# Altium schematic sheet TI2025_DiffDrv.SchDoc(TI2025_DiffDrv)
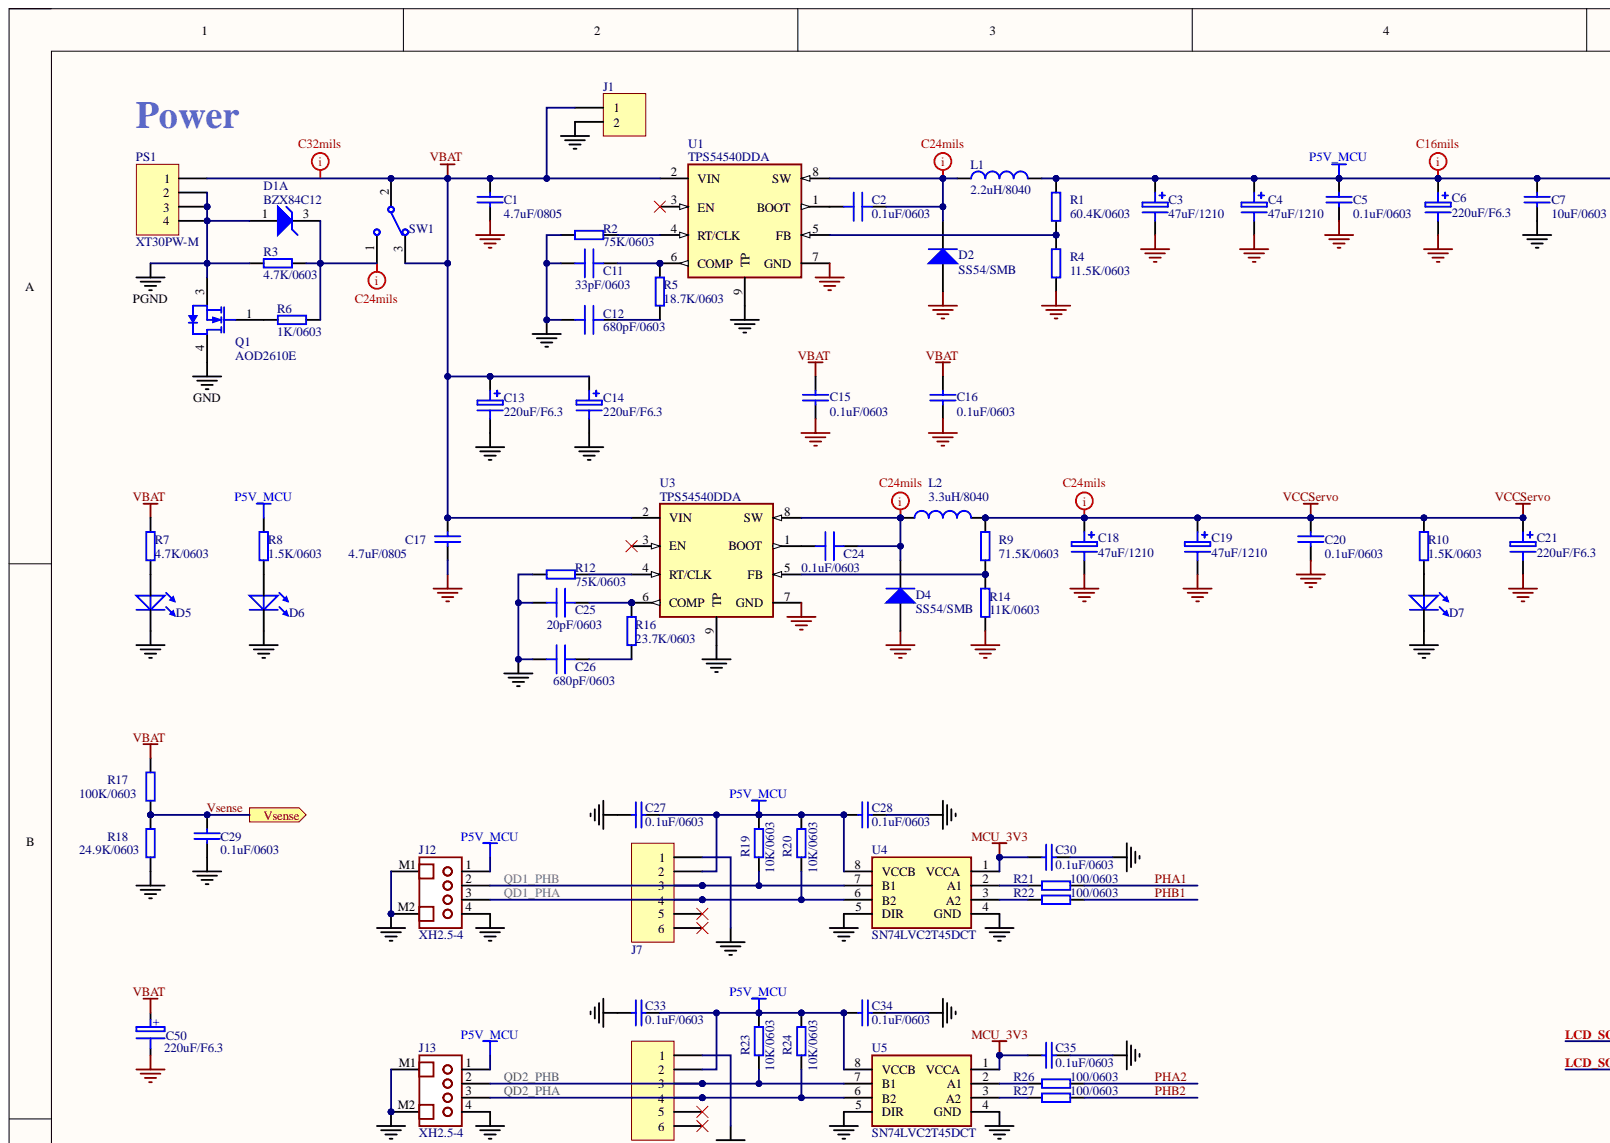
[diagram p0: TI2025_DiffDrv.SchDoc, top left]
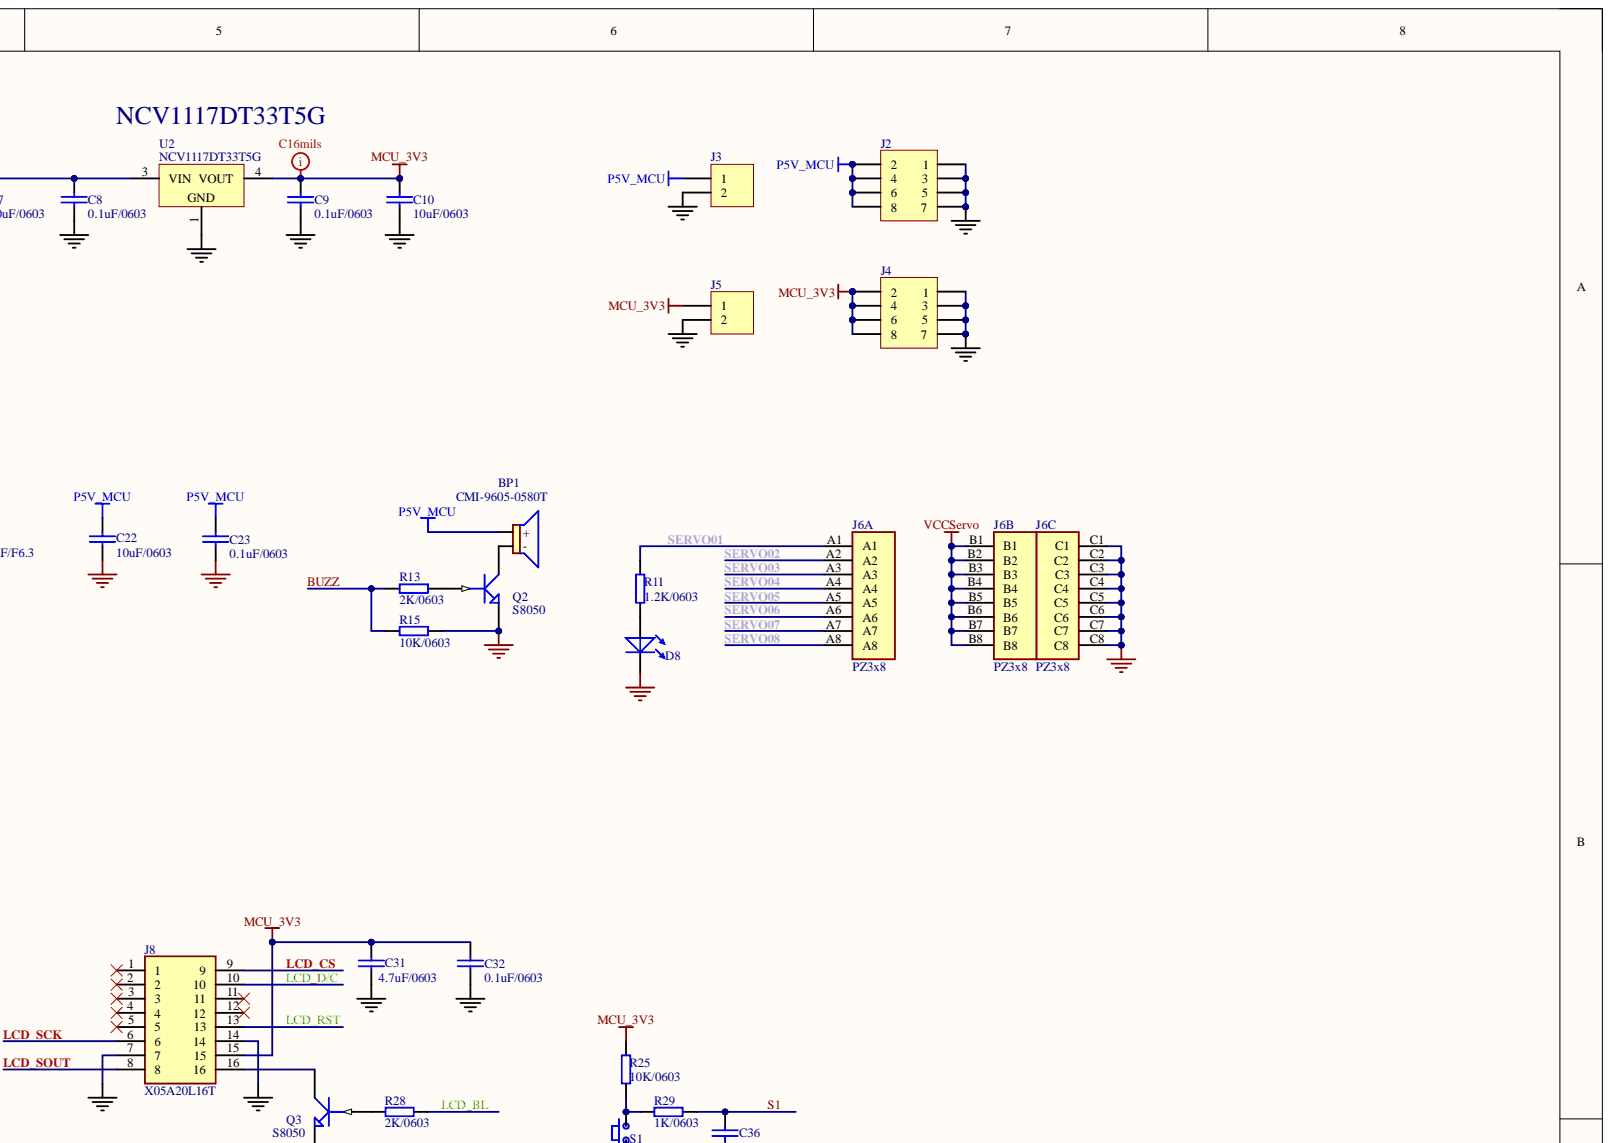
[diagram p1: TI2025_DiffDrv.SchDoc, top right]
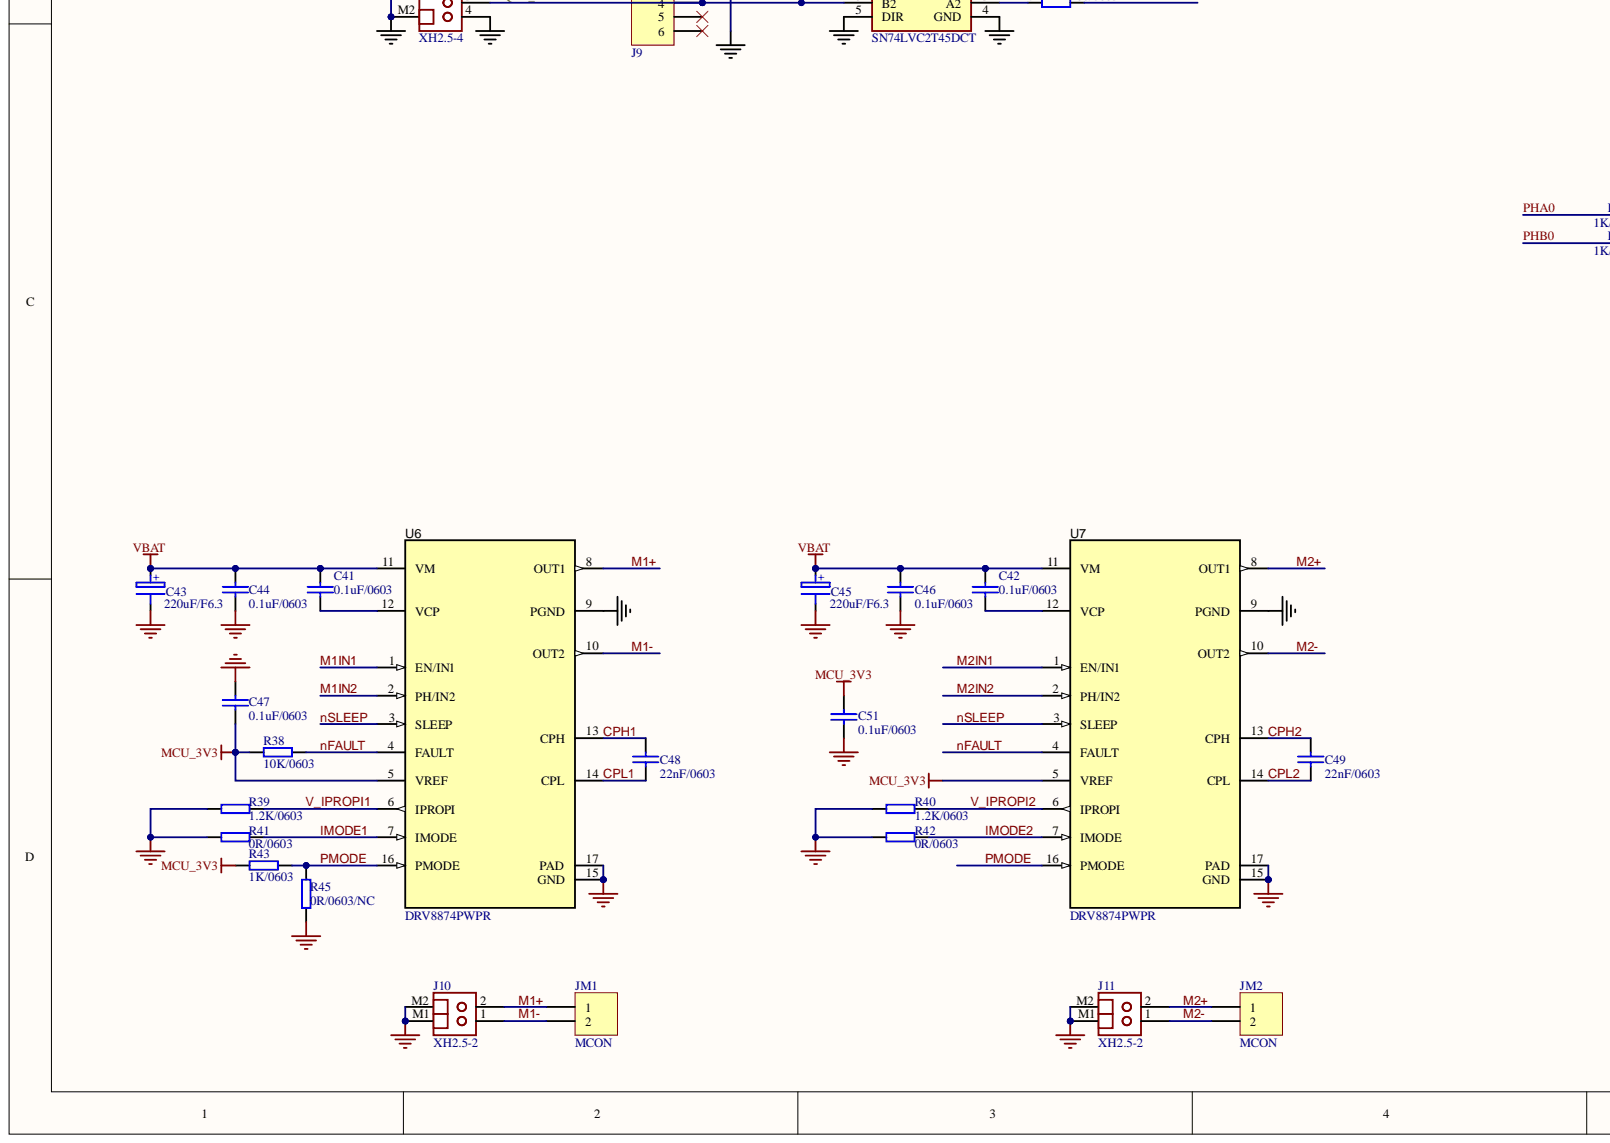
[diagram p2: TI2025_DiffDrv.SchDoc, bottom left]
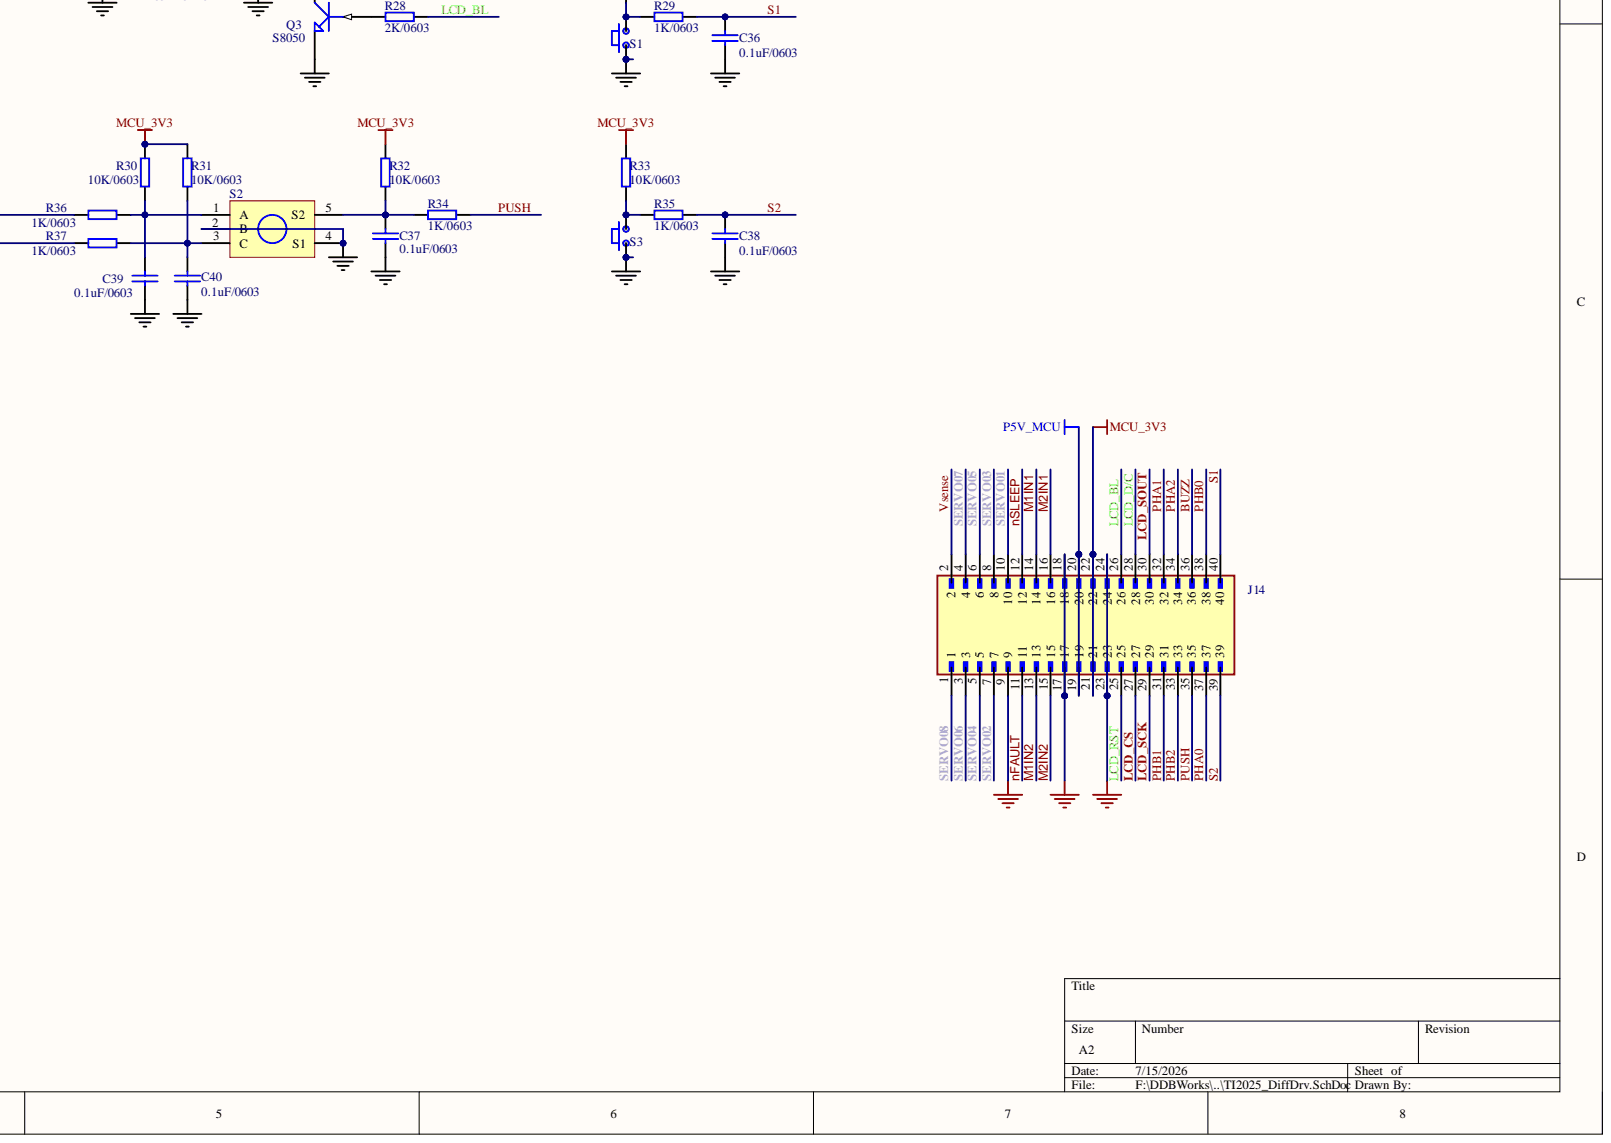
[diagram p3: TI2025_DiffDrv.SchDoc, bottom right]

## Parts
BP1  CMI-9605-0580T  [BUZZER_D9.6_P5.0]
C1  4.7uF/0805  [C0805J]
C2  0.1uF/0603  [C0603J]
C3  47uF/1210  [C1210J]
C4  47uF/1210  [C1210J]
C5  0.1uF/0603  [C0603J]
C6  220uF/F6.3  [SMD-EC-F6.3]
C7  10uF/0603  [C0603J]
C8  0.1uF/0603  [C0603J]
C9  0.1uF/0603  [C0603J]
C10  10uF/0603  [C0603J]
C11  33pF/0603  [C0603J]
C12  680pF/0603  [C0603J]
C13  220uF/F6.3  [SMD-EC-F6.3]
C14  220uF/F6.3  [SMD-EC-F6.3]
C15  0.1uF/0603  [C0603J]
C16  0.1uF/0603  [C0603J]
C17  4.7uF/0805  [C0805J]
C18  47uF/1210  [C1210J]
C19  47uF/1210  [C1210J]
C20  0.1uF/0603  [C0603J]
C21  220uF/F6.3  [SMD-EC-F6.3]
C22  10uF/0603  [C0603J]
C23  0.1uF/0603  [C0603J]
C24  0.1uF/0603  [C0603J]
C25  20pF/0603  [C0603J]
C26  680pF/0603  [C0603J]
C27  0.1uF/0603  [C0603J]
C28  0.1uF/0603  [C0603J]
C29  0.1uF/0603  [C0603J]
C30  0.1uF/0603  [C0603J]
C31  4.7uF/0603  [C0603J]
C32  0.1uF/0603  [C0603J]
C33  0.1uF/0603  [C0603J]
C34  0.1uF/0603  [C0603J]
C35  0.1uF/0603  [C0603J]
C36  0.1uF/0603  [C0603J]
C37  0.1uF/0603  [C0603J]
C38  0.1uF/0603  [C0603J]
C39  0.1uF/0603  [C0603J]
C40  0.1uF/0603  [C0603J]
C41  0.1uF/0603  [C0603J]
C42  0.1uF/0603  [C0603J]
C43  220uF/F6.3  [SMD-EC-F6.3]
C44  0.1uF/0603  [C0603J]
C45  220uF/F6.3  [SMD-EC-F6.3]
C46  0.1uF/0603  [C0603J]
C47  0.1uF/0603  [C0603J]
C48  22nF/0603  [C0603J]
C49  22nF/0603  [C0603J]
C50  220uF/F6.3  [SMD-EC-F6.3]
C51  0.1uF/0603  [C0603J]
D1  BZX84C12  [SOT23J]  (part A)
D2  SS54/SMB  [SMB_DTE]
D4  SS54/SMB  [SMB_DTE]
D5  LED/0603/R  [D0603J]  (value not drawn)
D6  LED/0603/R  [D0603J]  (value not drawn)
D7  LED/0603/R  [D0603J]  (value not drawn)
D8  LED/0603/R  [D0603J]  (value not drawn)
J1  (no value)  [CONN-2P-V3.5]
J2  2.54-2*4P  [IDC8DTE]  (value not drawn)
J3  (no value)  [CONN-2P-V3.5]
J4  2.54-2*4P  [IDC8DTE]  (value not drawn)
J5  (no value)  [CONN-2P-V3.5]
J6  PZ3x8  [PZ3x8-2.54]  (parts A,B,C)
J7  IDC2.54-6P  [IDC6Socket]  (value not drawn)
J8  X05A20L16T  [X05A20L16T]
J9  IDC2.54-6P  [IDC6Socket]  (value not drawn)
J10  XH2.5-2  [CONN-2P-XH2.5]
J11  XH2.5-2  [CONN-2P-XH2.5]
J12  XH2.5-4  [CONN-4P-XH2.5]
J13  XH2.5-4  [CONN-4P-XH2.5]
J14  IDC40P  [IDC40J]  (value not drawn)
JM1  MCON  [EDGRC-3.5-2P]
JM2  MCON  [EDGRC-3.5-2P]
L1  2.2uH/8040  [SWPA8040S]
L2  3.3uH/8040  [SWPA8040S]
PS1  XT30PW-M  [XT30PW-M]
Q1  AOD2610E  [TO-252A]
Q2  S8050  [S8050LT]
Q3  S8050  [S8050LT]
R1  60.4K/0603  [R0603J]
R2  75K/0603  [R0603J]
R3  4.7K/0603  [R0603J]
R4  11.5K/0603  [R0603J]
R5  18.7K/0603  [R0603J]
R6  1K/0603  [R0603J]
R7  4.7K/0603  [R0603J]
R8  1.5K/0603  [R0603J]
R9  71.5K/0603  [R0603J]
R10  1.5K/0603  [R0603J]
R11  1.2K/0603  [R0603J]
R12  75K/0603  [R0603J]
R13  2K/0603  [R0603J]
R14  11K/0603  [R0603J]
R15  10K/0603  [R0603J]
R16  23.7K/0603  [R0603J]
R17  100K/0603  [R0603J]
R18  24.9K/0603  [R0603J]
R19  10K/0603  [R0603J]
R20  10K/0603  [R0603J]
R21  100/0603  [R0603J]
R22  100/0603  [R0603J]
R23  10K/0603  [R0603J]
R24  10K/0603  [R0603J]
R25  10K/0603  [R0603J]
R26  100/0603  [R0603J]
R27  100/0603  [R0603J]
R28  2K/0603  [R0603J]
R29  1K/0603  [R0603J]
R30  10K/0603  [R0603J]
R31  10K/0603  [R0603J]
R32  10K/0603  [R0603J]
R33  10K/0603  [R0603J]
R34  1K/0603  [R0603J]
R35  1K/0603  [R0603J]
R36  1K/0603  [R0603J]
R37  1K/0603  [R0603J]
R38  10K/0603  [R0603J]
R39  1.2K/0603  [R0603J]
R40  1.2K/0603  [R0603J]
R41  0R/0603  [R0603J]
R42  0R/0603  [R0603J]
R43  1K/0603  [R0603J]
R45  0R/0603/NC  [R0603J]
S1  PB2  [PB2P_THRU]  (value not drawn)
S2  QES  [QES_THRU]  (value not drawn)
S3  PB2  [PB2P_THRU]  (value not drawn)
SW1  SS12D10G4  [SS12D10]  (value not drawn)
U1  TPS54540DDA  [SOIC8DTE_EP]
U2  NCV1117DT33T5G  [TO-252A]
U3  TPS54540DDA  [SOIC8DTE_EP]
U4  SN74LVC2T45DCT  [DCT_SSOP_SM8]
U5  SN74LVC2T45DCT  [DCT_SSOP_SM8]
U6  DRV8874PWPR  [PWP0016J_N]
U7  DRV8874PWPR  [PWP0016J_N]

## Ports
Vsense

## Nets
GND: C1.1 C3.2 C4.2 C5.1 C6.2 C7.2 C8.1 C9.1 C10.2 C11.2 C12.2 C13.2 C14.2 C15.2 C16.2 C17.1 C18.2 C19.2 C20.1 C21.2 C22.1 C23.1 C25.2 C26.2 C27.2 C28.1 C29.1 C30.1 C31.2 C32.1 C33.2 C34.1 C35.1 C36.1 C37.2 C38.1 C39.2 C40.2 C43.2 C44.1 C45.2 C46.1 C47.1 C50.2 C51.2 D2.1 D4.1 D5.K D6.K D7.K D8.K J1.2 J2.1 J2.3 J2.5 J2.7 J3.2 J4.1 J4.3 J4.5 J4.7 J5.2 J6.C1 J6.C2 J6.C3 J6.C4 J6.C5 J6.C6 J6.C7 J6.C8 J7.1 J8.7 J8.14 J9.1 J10.M1 J10.M2 J11.M1 J11.M2 J12.4 J12.M1 J12.M2 J13.4 J13.M1 J13.M2 J14.9 J14.17 J14.18 J14.23 J14.24 Q1.4 Q2.3 Q3.3 R2.1 R4.1 R12.1 R14.1 R15.1 R18.1 R39.1 R40.1 R41.1 R42.1 R45.1 S1.1 S2.2(B) S2.4(S1) S3.1 U1.7(GND) U1.9(TP) U2.1 U3.7(GND) U3.9(TP) U4.4(GND) U4.5(DIR) U5.4(GND) U5.5(DIR) U6.9(PGND) U6.15(GND) U6.17(PAD) U7.9(PGND) U7.15(GND) U7.17(PAD)
MCU_3V3: C9.2 C10.1 C30.2 C31.1 C32.2 C35.2 C47.2 C51.1 J4.2 J4.4 J4.6 J4.8 J5.1 J8.15 J14.21 J14.22 R25.1 R30.1 R31.1 R32.1 R33.1 R38.1 R43.1 U2.4 U4.1(VCCA) U5.1(VCCA) U6.5(VREF) U7.5(VREF)
P5V_MCU: BP1.1 C3.1 C4.1 C5.2 C6.1 C7.1 C8.2 C22.2 C23.2 C27.1 C28.2 C33.1 C34.2 J2.2 J2.4 J2.6 J2.8 J3.1 J7.2 J9.2 J12.1 J13.1 J14.19 J14.20 L1.2 R1.1 R8.2 R19.1 R20.1 R23.1 R24.1 U2.3 U4.8(VCCB) U5.8(VCCB)
PGND: D1.1 PS1.2 PS1.3 PS1.4 Q1.3 R3.2
VBAT: C1.2 C13.1 C14.1 C15.1 C16.1 C17.2 C41.2 C42.2 C43.1 C44.2 C45.1 C46.2 C50.1 J1.1 PS1.1 R7.2 R17.2 SW1.2 SW1.3 U1.2(VIN) U3.2(VIN) U6.11(VM) U7.11(VM)
VCCServo: C18.1 C19.1 C20.2 C21.1 J6.B1 J6.B2 J6.B3 J6.B4 J6.B5 J6.B6 J6.B7 J6.B8 L2.2 R9.1 R10.1
BUZZ: J14.36 R13.1 R15.2
CPH1: C48.2 U6.13(CPH)
CPH2: C49.2 U7.13(CPH)
CPL1: C48.1 U6.14(CPL)
CPL2: C49.1 U7.14(CPL)
IMODE1: R41.2 U6.7(IMODE)
IMODE2: R42.2 U7.7(IMODE)
LCD_BL: J14.26 R28.2
LCD_CS: J8.9 J14.27
LCD_D/C: J8.10 J14.28
LCD_RST: J8.13 J14.25
LCD_SCK: J8.6 J14.29
LCD_SOUT: J8.8 J14.30
M1+: J10.2 JM1.1 U6.8(OUT1)
M1-: J10.1 JM1.2 U6.10(OUT2)
M1IN1: J14.14 U6.1(EN/IN1)
M1IN2: J14.13 U6.2(PH/IN2)
M2+: J11.2 JM2.1 U7.8(OUT1)
M2-: J11.1 JM2.2 U7.10(OUT2)
M2IN1: J14.16 U7.1(EN/IN1)
M2IN2: J14.15 U7.2(PH/IN2)
nFAULT: J14.11 R38.2 U6.4(FAULT) U7.4(FAULT)
nSLEEP: J14.12 U6.3(SLEEP) U7.3(SLEEP)
PHA0: J14.37 R36.1
PHA1: J14.32 R21.2
PHA2: J14.34 R26.2
PHB0: J14.38 R37.1
PHB1: J14.31 R22.2
PHB2: J14.33 R27.2
PMODE: R43.2 R45.2 U6.16(PMODE) U7.16(PMODE)
PUSH: J14.35 R34.2
QD1_PHA: J7.4 J12.3 R20.2 U4.6(B2)
QD1_PHB: J7.3 J12.2 R19.2 U4.7(B1)
QD2_PHA: J9.4 J13.3 R24.2 U5.6(B2)
QD2_PHB: J9.3 J13.2 R23.2 U5.7(B1)
S1: C36.2 J14.40 R29.2
S2: C38.2 J14.39 R35.2
SERVO01: J6.A1 J14.10 R11.2
SERVO02: J6.A2 J14.7
SERVO03: J6.A3 J14.8
SERVO04: J6.A4 J14.5
SERVO05: J6.A5 J14.6
SERVO06: J6.A6 J14.3
SERVO07: J6.A7 J14.4
SERVO08: J6.A8 J14.1
V_IPROPI1: R39.2 U6.6(IPROPI)
V_IPROPI2: R40.2 U7.6(IPROPI)
Vsense: C29.2 J14.2 R17.1 R18.2 ; port Vsense
NetBP1_2: BP1.2 Q2.2
NetC2_1: C2.1 D2.2 L1.1 U1.8(SW)
NetC2_2: C2.2 U1.1(BOOT)
NetC11_1: C11.1 R5.2 U1.6(COMP)
NetC12_1: C12.1 R5.1
NetC24_1: C24.1 D4.2 L2.1 U3.8(SW)
NetC24_2: C24.2 U3.1(BOOT)
NetC25_1: C25.1 R16.2 U3.6(COMP)
NetC26_1: C26.1 R16.1
NetC37_1: C37.1 R32.2 R34.1 S2.5
NetC39_1: C39.1 R30.2 R36.2 S2.1(A)
NetC40_1: C40.1 R31.2 R37.2 S2.3(C)
NetC41_1: C41.1 U6.12(VCP)
NetC42_1: C42.1 U7.12(VCP)
NetD1_3: D1.3 R3.1 R6.1 SW1.1
NetD5_A: D5.A R7.1
NetD6_A: D6.A R8.1
NetD7_A: D7.A R10.2
NetD8_A: D8.A R11.1
NetJ8_16: J8.16 Q3.2
NetQ1_1: Q1.1 R6.2
NetQ2_1: Q2.1 R13.2
NetQ3_1: Q3.1 R28.1
NetR1_2: R1.2 R4.2 U1.5(FB)
NetR2_2: R2.2 U1.4(RT/CLK)
NetR9_2: R9.2 R14.2 U3.5(FB)
NetR12_2: R12.2 U3.4(RT/CLK)
NetR21_1: R21.1 U4.2(A1)
NetR22_1: R22.1 U4.3(A2)
NetR25_2: R25.2 R29.1 S1.2
NetR26_1: R26.1 U5.2(A1)
NetR27_1: R27.1 U5.3(A2)
NetR33_2: R33.2 R35.1 S3.2

Not connected: J7 5 6; J8 1 2 3 4 5 11 12; J9 5 6; U1 3(EN); U3 3(EN)

## Library data of the parts
BP1 = BUZZER: C200213; Component Kind: Standard; Library Name: ELVIS4.SchLib
C1 C17 = CAP: C1779; Component Kind: Standard; Part Field 1: 8-Jun-2000; Part Field 2: July-2002: Re-released for DXP Platform.; Part Field 3: Altium Limited; Part Field 4: 30pF; PCB3D: 0805_0_0000_C
C2 C24 = Ca_DTE: C14663; Component Kind: Standard; Library Name: DTE1.SchLib
C3 C4 C18 C19 = CAPPOLSMD: C77101; Component Kind: Standard
C5 C8 C9 C15 C16 C20 C23 C27-C30 C32-C36 C38 C41 C42 C44 C46 C47 C51 = CAP: C14663; Component Kind: Standard; Part Field 1: 8-Jun-2000; Part Field 2: July-2002: Re-released for DXP Platform.; Part Field 3: Altium Limited; Part Field 4: 30pF; PCB3D: 0805_0_0000_C
C6 C13 C14 C21 = CAPPOLSMD: C4747975; Component Kind: Standard
C7 C10 C22 = CAP: C96446; Component Kind: Standard; Part Field 1: 8-Jun-2000; Part Field 2: July-2002: Re-released for DXP Platform.; Part Field 3: Altium Limited; Part Field 4: 30pF; PCB3D: 0805_0_0000_C
C11 = Ca_DTE: C1658; Component Kind: Standard; Library Name: DTE1.SchLib
C12 C26 = Ca_DTE: C1630; Component Kind: Standard; Library Name: DTE1.SchLib
C25 = Ca_DTE: C1648; Component Kind: Standard; Library Name: DTE1.SchLib
C31 = CAP: C19666; Component Kind: Standard; Part Field 1: 8-Jun-2000; Part Field 2: July-2002: Re-released for DXP Platform.; Part Field 3: Altium Limited; Part Field 4: 30pF; PCB3D: 0805_0_0000_C
C37 C39 C40 = CAP: C14663; Part Field 1: C1525; Component Kind: Standard; Part Field 2: CL05B104KO5NNNC; Part Field 3: Altium Limited; Part Field 4: 0.1uF 16V
C43 C45 C50 = Cb_DTE: C4747975; Component Kind: Standard; Library Name: DTE1.SchLib; PCB3D: C3216-1206_0_0000_C
C48 C49 = CAP: C21122; Component Kind: Standard; Part Field 1: 8-Jun-2000; Part Field 2: July-2002: Re-released for DXP Platform.; Part Field 3: Altium Limited; Part Field 4: 30pF; PCB3D: 0805_0_0000_C
D1 = BZX84C12LT1G: C82475; Manufacturer: On Semiconductor; Packing: Tape and Reel; Component Kind: Standard; ComponentLink1Description: Manufacturer URL; ComponentLink1URL: http://www.onsemi.com/; ComponentLink2Description: Datasheet; ComponentLink2URL: http://www.onsemi.com/pub_link/Collateral/BZX84C2V4LT1-D.PDF; ComponentLink3Description: Package Specification; ComponentLink3URL: http://www.onsemi.cn/pub_link/Collateral/318-08.PDF; DatasheetVersion: Rev. 17; Library Name: ON Semi Zener Diodes.IntLib; Mounting Technology: Surface Mount; PackageDescription: 3-Pin Small Outline Transistor, Body 2.92 x 1.3 mm, Pitch 0.95 mm; PackageReference: SOT-23-3-318-08; PackageVersion: Rev. AP, 11/2009; PartNumber: BZX84C12LT1G; RoHS: Pb-Free; Supplier 1: Digi-Key; Supplier 2: Digi-Key; Supplier 3: Digi-Key; Supplier 4: Farnell; Supplier 5: Farnell; Supplier 6: Farnell; Supplier 7: Newark; Supplier 8: Newark; Supplier 9: Mouser; Supplier Part Number 1: BZX84C12LT1GOSTR-ND; Supplier Part Number 2: BZX84C12LT1GOSCT-ND; Supplier Part Number 3: BZX84C12LT1GOSDKR-ND; Supplier Part Number 4: 1459049; Supplier Part Number 5: 1459049RL; Supplier Part Number 6: 2317723; Supplier Part Number 7: 83H6453; Supplier Part Number 8: 67R1296; Supplier Part Number 9: 863-BZX84C12LT1G
D2 D4 = SMA(DO-214AC) SS54: C32513; Component Kind: Standard; ComponentLink1Description: 供应商链接; ComponentLink1URL: http://www.szlcsc.com/product/details_23178.html; Library Name: Miscellaneous Devices LC.IntLib; Package: SMA(DO-214AC); Supplier: LC; SuppliersPartNumber: C22452
D5-D8 = LEDa_DTE: C2286; Component Kind: Standard; Library Name: DTE1.SchLib; PCB3D: LED
J1 J3 J5 JM1 JM2 = Header 2: C441171; Component Kind: Standard; LatestRevisionDate: 17-Jul-2002; LatestRevisionNote: Re-released for DXP Platform.; Library Name: Miscellaneous Connectors.IntLib; Signal Integrity: Connector; Publisher: Altium Limited
J2 J4 = Header 4X2: C225519; Component Kind: Standard; LatestRevisionDate: 17-Jul-2002; LatestRevisionNote: Re-released for DXP Platform.; Library Name: Miscellaneous Connectors.IntLib; Signal Integrity: Connector; Publisher: Altium Limited
J6 = PZ3x8: C32713295; Component Kind: Standard; Library Name: HSP.SchLib
J7 J9 = Header 6: C11214; Component Kind: Standard; LatestRevisionDate: 17-Jul-2002; LatestRevisionNote: Re-released for DXP Platform.; Library Name: Miscellaneous Connectors.IntLib; Signal Integrity: Connector; Publisher: Altium Limited
J8 = X05B20L16T: C2880805; Manufacturer_Name: XKB Connectivity; Component Kind: Standard; Datasheet Link: http://www.helloxkb.com/public/images/pdf/X05B20L.pdf; Height: 2mm; Manufacturer_Part_Number: X05B20L16T
J10 J11 = CONN-2P2M: C3029476; Component Kind: Standard; Library Name: HSP.SchLib
J12 J13 = CONN-4P2M: C7429673; Component Kind: Standard; Library Name: HSP.SchLib
J14 = CONN_B2B_40P: C124383; Component Kind: Standard; Library Name: HSP.SchLib
L1 = Inductor: C36415; Code_IEC: 1005; Value: C10148; Code_JEITA: 0402; Component Kind: Standard; LatestRevisionDate: 29-May-2009; LatestRevisionNote: IPC-7351 Footprint Added.; Library Name: MyLib.IntLib; PackageDescription: Chip Inductor; PackageReference: 0402-A; Published: 8-Jun-2000; Signal Integrity: Inductor; Simulation: INDUCTOR; Publisher: Altium Limited
L2 = Inductor: C83464; Code_IEC: 1005; Value: C10148; Code_JEITA: 0402; Component Kind: Standard; LatestRevisionDate: 29-May-2009; LatestRevisionNote: IPC-7351 Footprint Added.; Library Name: MyLib.IntLib; PackageDescription: Chip Inductor; PackageReference: 0402-A; Published: 8-Jun-2000; Signal Integrity: Inductor; Simulation: INDUCTOR; Publisher: Altium Limited
PS1 = Header 4: C431092; Component Kind: Standard; LatestRevisionDate: 17-Jul-2002; LatestRevisionNote: Re-released for DXP Platform.; Library Name: Miscellaneous Connectors.IntLib; Signal Integrity: Connector; Publisher: Altium Limited
Q1 = NTD4858NT4G: C282428; Manufacturer: On Semiconductor; Channel Polarity: N Channel; Ciss Typ (pF): 1563; Configuration: Single; Coss Typ (pF): 405; Crss Typ (pF): 200; ID Max (A): 73; Packing: Tape and Reel; Qrr Typ (nC): 3; V(BR)DSS Min (V): 25; VGS Max (V): 20; VGS(th) Max (V): 2.5; Component Kind: Standard; ComponentLink1Description: Manufacturer URL; ComponentLink1URL: http://www.onsemi.com/; ComponentLink2Description: Datasheet; ComponentLink2URL: http://www.onsemi.cn/pub_link/Collateral/NTD4858N-D.PDF; ComponentLink3Description: Package Specification; ComponentLink3URL: http://www.onsemi.cn/pub_link/Collateral/369AA.PDF; DatasheetVersion: Rev. 2; Library Name: ON Semi MOSFETs.IntLib; Mounting Technology: Surface Mount; Package Type: DPAK-3, IPAK-3; PackageDescription: 3-Pin Flange Mount, Body 6.54 x 6.095 mm, Pitch 2.29 mm; PackageReference: DPAK-3-369AA-01; PackageVersion: Rev. B, 06/2010; PartNumber: NTD4858NT4G; RoHS: Pb-Free
Q2 Q3 = NPN1: C2146; Component Kind: Standard; Library Name: CyberDuck.SCHLIB
R1 = Ra_DTE: C23089; Component Kind: Standard; Library Name: DTE1.SchLib
R2 R12 = Ra_DTE: C23242; Component Kind: Standard; Library Name: DTE1.SchLib
R3 R7 = Ra_DTE: C23162; Component Kind: Standard; Library Name: DTE1.SchLib; PCB3D: 0805_0_0000_R
R4 = Ra_DTE: C25949; Component Kind: Standard; Library Name: DTE1.SchLib
R5 = Ra_DTE: C22893; Component Kind: Standard; Library Name: DTE1.SchLib
R6 R29 R34-R37 R43 = Ra_DTE: C21190; Component Kind: Standard; Library Name: DTE1.SchLib
  R6 R29 R34 R35 R37 R43: PCB3D: 0805_0_0000_R
R8 R10 = Ra_DTE: C22843; Component Kind: Standard; Library Name: DTE1.SchLib; PCB3D: 0805_0_0000_R
R9 = Ra_DTE: C23103; Component Kind: Standard; Library Name: DTE1.SchLib
R11 R39 R40 = Ra_DTE: C22765; Component Kind: Standard; Library Name: DTE1.SchLib; PCB3D: 0805_0_0000_R
R13 R28 = Ra_DTE: C22975; Component Kind: Standard; Library Name: DTE1.SchLib; PCB3D: 0805_0_0000_R
R14 = Ra_DTE: C25950; Component Kind: Standard; Library Name: DTE1.SchLib
R15 R19 R20 R23-R25 R30-R33 R38 = Ra_DTE: C25804; Parameter 1: C25744; Parameter 2: 0402WGF1002TCE; Parameter 3: 10K 0402; Component Kind: Standard; Library Name: DTE1.SchLib
R16 = Ra_DTE: C22912; Component Kind: Standard; Library Name: DTE1.SchLib
R17 = Ra_DTE: C25803; Component Kind: Standard; Library Name: DTE1.SchLib; PCB3D: 0805_0_0000_R
R18 = Ra_DTE: C25962; Component Kind: Standard; Library Name: DTE1.SchLib; PCB3D: 0805_0_0000_R
R21 R22 R26 R27 = Ra_DTE: C22775; Component Kind: Standard; Library Name: DTE1.SchLib; PCB3D: 0805_0_0000_R
R41 R42 R45 = Ra_DTE: C21189; Component Kind: Standard; Library Name: DTE1.SchLib; PCB3D: 0805_0_0000_R
S1 S3 = PressButton: C2837071; Component Kind: Standard; Library Name: DTE1.SchLib
S2 = ALPS_QES: C2991196; Component Kind: Standard
SW1 = SW-SPDT: C5149841; Component Kind: Standard; Price(RMB): 0.000; Published: 24-Mar-1999; Library Name: 钮子开关原理.SchLib; Publisher: Altium Limited
U1 U3 = TPS54340DDA: C95286; Component Kind: Standard; Library Name: DTE_LibS.SchLib
U2 = LD1117DT33TR: C20625; Component Kind: Standard; ComponentLink1Description: Manufacturer URL; ComponentLink1URL: http://www.st.com; ComponentLink2Description: Datasheet; ComponentLink2URL: http://www.st.com/internet/com/TECHNICAL_RESOURCES/TECHNICAL_LITERATURE/DATASHEET/CD00000544.pdf; DatasheetVersion: Nov-2011; Library Name: STMicroelectronics Power Management Linear Regulator.IntLib; PackageDescription: 3-Pin Transistor Outline Package 2.28 mm Pitch, 9.7 mm Lead Span (DPAK); PackageReference: TO-252; PackageVersion: 2011; PartNumber: LD1117DT33TR
U4 U5 = SN74LVC2T45DCUR: C22672; Manufacturer_Name: Texas Instruments; Arrow Part Number: SN74LVC2T45DCUR; Arrow Price/Stock: https://www.arrow.com/en/products/sn74lvc2t45dcur/texas-instruments?region=nac; Component Kind: Standard; Datasheet Link: http://www.ti.com/lit/gpn/sn74lvc2t45; Height: 0.9mm; Manufacturer_Part_Number: SN74LVC2T45DCUR; Mouser Part Number: 595-SN74LVC2T45DCUR; Mouser Price/Stock: https://www.mouser.co.uk/ProductDetail/Texas-Instruments/SN74LVC2T45DCUR?qs=0smPjiIwnfIdG9vxyJHBdA%3D%3D
U6 = CMP-0081845-1: H-Bridge Motor Drivers With Integrated Current Sense and Regulation, PWP0016J (HTSSOP-16); Alternate Manufacturer: Texas Instruments; AEC Category: Q200; AEC Qualified: N; CompType: IC; SizeArea_mm2: 32; SizeAreaWB_mm2: 58.8; SizeX_mm: 6.4; SizeY_mm: 5; SizeZ_mm: 1.2; Alternate PartNumber: DRV8874PWP; Component Kind: Standard; ComponentLink1Description: Datasheet Link; ComponentLink1URL: http://www.ti.com/lit/ds/symlink/drv8874.pdf; ComponentLink2Description: Product Folder; ComponentLink2URL: http://www.ti.com/product/drv8874; ComponentLink3Description: Online Datasheet; ComponentLink3URL: http://www.ti.com/product/drv8874/datasheet; Library Name: Altium Vault - TI; Manufacturer: Texas Instruments; MountType: SMT; PackageDescription: HTSSOP-16; PackageReference: PWP0016J; PartNumber: DRV8874PWPR
U7 = CMP-0081845-1: C1855818; Alternate Manufacturer: Texas Instruments; AEC Category: Q200; AEC Qualified: N; CompType: IC; SizeArea_mm2: 32; SizeAreaWB_mm2: 58.8; SizeX_mm: 6.4; SizeY_mm: 5; SizeZ_mm: 1.2; Alternate PartNumber: DRV8874PWP; Component Kind: Standard; ComponentLink1Description: Datasheet Link; ComponentLink1URL: http://www.ti.com/lit/ds/symlink/drv8874.pdf; ComponentLink2Description: Product Folder; ComponentLink2URL: http://www.ti.com/product/drv8874; ComponentLink3Description: Online Datasheet; ComponentLink3URL: http://www.ti.com/product/drv8874/datasheet; Library Name: Altium Vault - TI; Manufacturer: Texas Instruments; MountType: SMT; PackageDescription: HTSSOP-16; PackageReference: PWP0016J; PartNumber: DRV8874PWPR
